# Altium schematic sheet ESP-MGMT.SchDoc
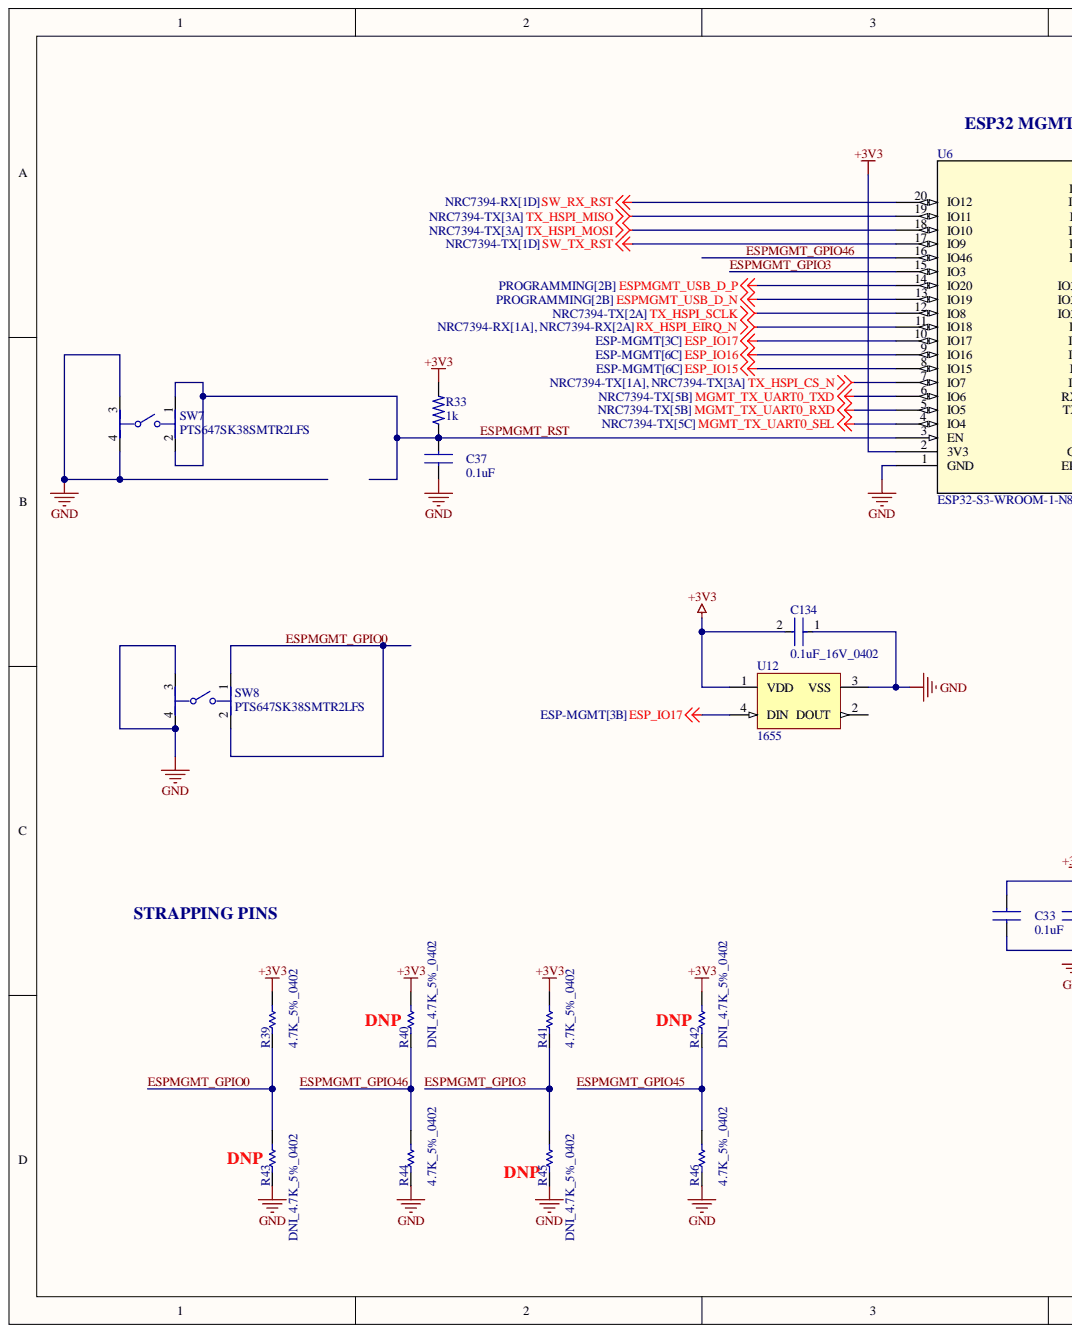
[diagram p0: ESP-MGMT.SchDoc, left]
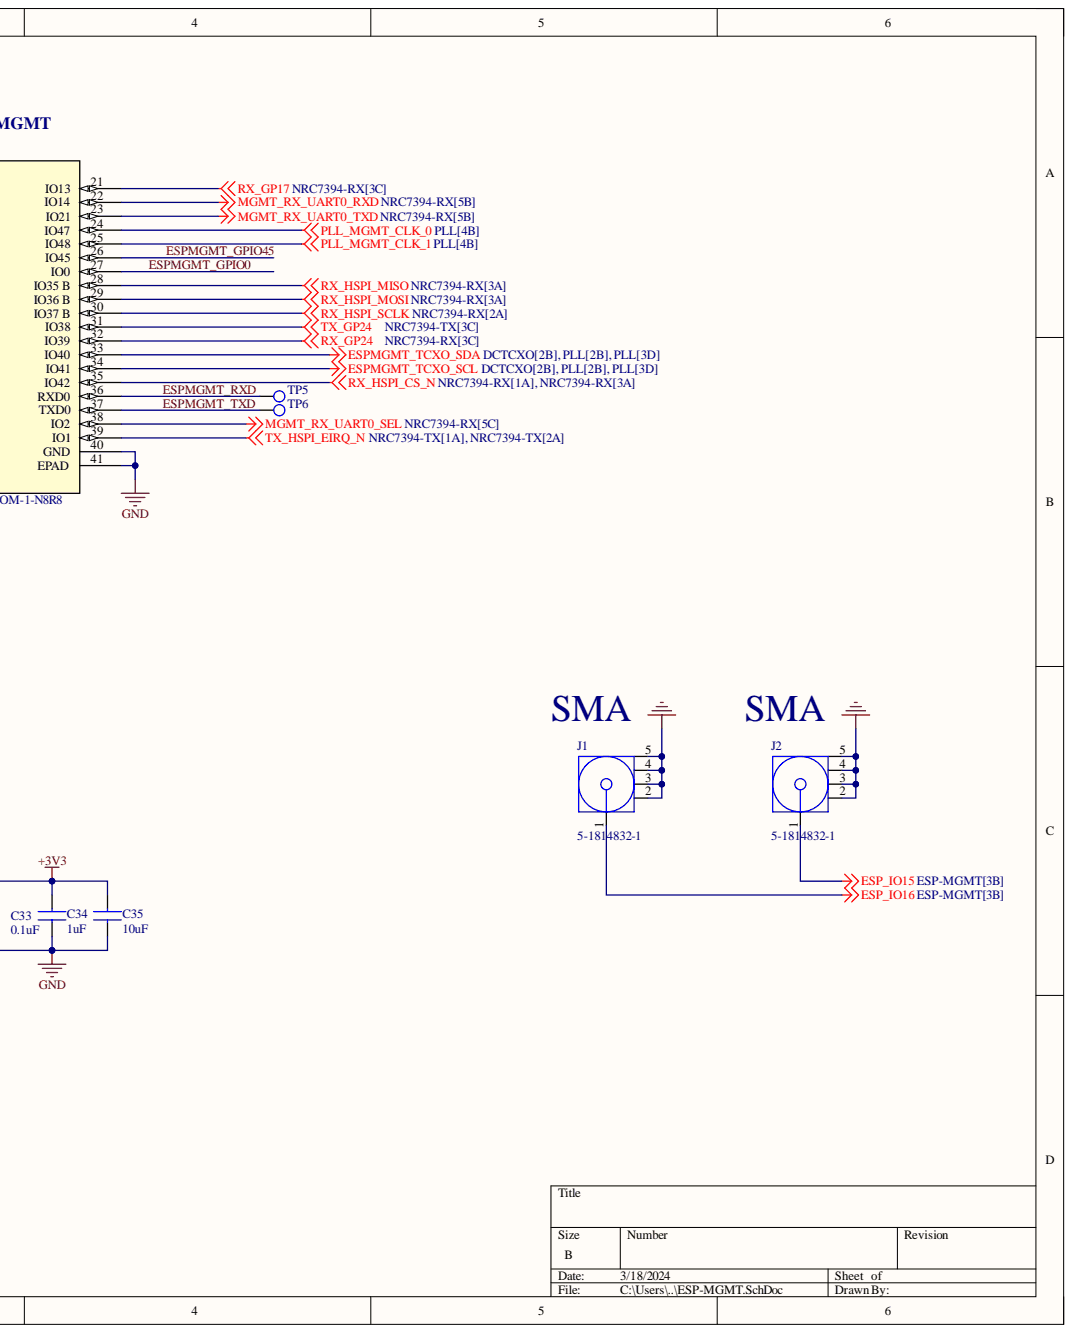
[diagram p1: ESP-MGMT.SchDoc, right]

## Parts
C33  0.1uF  [CAP_0402]
C34  1uF  [CAP_0402]
C35  10uF  [CAP_0402]
C37  0.1uF  [CAP_0402]
C134  0.1uF_16V_0402  [FP-C0402-BB-MFG]
J1  5-1814832-1  [TECO-5-1814832-1_V]
J2  5-1814832-1  [TECO-5-1814832-1_V]
R33  1k  [RES_0402]
R39  4.7K_5%_0402  [RESC1005X40X25LL05T05]
R40  DNI_4.7K_5%_0402  [RESC1005X40X25LL05T05]  (no BOM)
R41  4.7K_5%_0402  [RESC1005X40X25LL05T05]
R42  DNI_4.7K_5%_0402  [RESC1005X40X25LL05T05]  (no BOM)
R43  DNI_4.7K_5%_0402  [RESC1005X40X25LL05T05]  (no BOM)
R44  4.7K_5%_0402  [RESC1005X40X25LL05T05]
R45  DNI_4.7K_5%_0402  [RESC1005X40X25LL05T05]  (no BOM)
R46  4.7K_5%_0402  [RESC1005X40X25LL05T05]
SW7  PTS647SK38SMTR2LFS  [FP-PTS647SK38SMTR2LFS-MFG]
SW8  PTS647SK38SMTR2LFS  [FP-PTS647SK38SMTR2LFS-MFG]
TP5  Test Point 1MM  [TP_PAD-1MM]  (value not drawn)  (no BOM)
TP6  Test Point 1MM  [TP_PAD-1MM]  (value not drawn)  (no BOM)
U6  ESP32-S3-WROOM-1-N8R8  [ESP32-S3-WROOM-1_EXP]
U12  1655  [ADA-RGB-1655_V]

## Nets
+3V3: C33.2 C34.2 C35.2 C134.2 R33.2 R39.2 R40.2 R41.2 R42.2 U6.2(3V3) U12.1(VDD)
ESP_IO15: J2.1 U6.8(IO15)
ESP_IO16: J1.1 U6.9(IO16)
ESP_IO17: U6.10(IO17) U12.4(DIN)
ESPMGMT_TCXO_SCL: U6.34(IO41)
ESPMGMT_TCXO_SDA: U6.33(IO40)
ESPMGMT_USB_D_N: U6.13(IO19)
ESPMGMT_USB_D_P: U6.14(IO20)
GND: C33.1 C34.1 C35.1 C37.1 C134.1 J1.2 J1.3 J1.4 J1.5 J2.2 J2.3 J2.4 J2.5 R43.1 R44.1 R45.1 R46.1 SW7.3 SW7.4 SW8.3 SW8.4 U6.1(GND) U6.40(GND) U6.41(EPAD) U12.3(VSS)
MGMT_RX_UART0_RXD: U6.22(IO14)
MGMT_RX_UART0_SEL: U6.38(IO2)
MGMT_RX_UART0_TXD: U6.23(IO21)
MGMT_TX_UART0_RXD: U6.5(IO5)
MGMT_TX_UART0_SEL: U6.4(IO4)
MGMT_TX_UART0_TXD: U6.6(IO6)
PLL_MGMT_CLK_0: U6.24(IO47)
PLL_MGMT_CLK_1: U6.25(IO48)
RX_GP17: U6.21(IO13)
RX_GP24: U6.32(IO39)
RX_HSPI_CS_N: U6.35(IO42)
RX_HSPI_EIRQ_N: U6.11(IO18)
RX_HSPI_MISO: U6.28(IO35_B)
RX_HSPI_MOSI: U6.29(IO36_B)
RX_HSPI_SCLK: U6.30(IO37_B)
SW_RX_RST: U6.20(IO12)
SW_TX_RST: U6.17(IO9)
TX_GP24: U6.31(IO38)
TX_HSPI_CS_N: U6.7(IO7)
TX_HSPI_EIRQ_N: U6.39(IO1)
TX_HSPI_MISO: U6.19(IO11)
TX_HSPI_MOSI: U6.18(IO10)
TX_HSPI_SCLK: U6.12(IO8)
ESPMGMT_GPIO0: R39.1 R43.2 SW8.1 SW8.2 U6.27(IO0)
ESPMGMT_GPIO3: R41.1 R45.2 U6.15(IO3)
ESPMGMT_GPIO45: R42.1 R46.2 U6.26(IO45)
ESPMGMT_GPIO46: R40.1 R44.2 U6.16(IO46)
ESPMGMT_RST: C37.2 R33.1 SW7.1 SW7.2 U6.3(EN)
ESPMGMT_RXD: TP5.1 U6.36(RXD0)
ESPMGMT_TXD: TP6.1 U6.37(TXD0)

Not connected: U12 2(DOUT)

## Library data of the parts
C33 C37 = CAP_GCM155R71C104KA55J: Cap Ceramic 0.1uF 16V X7R 10% SMD 0402 125C Paper T/R; Manufacturer: Murata; Capacitance: 100nF; Case Code (Imperial): 0402; Case Code (Metric): 1005; Case/Package: 0402; Category: Ceramic Capacitors; Component Kind: Standard; ComponentLink1Description: https://datasheet.ciiva.com/11618/product-11618848.pdf?src-supplier=Avnet; ComponentLink1URL: https://datasheet.ciiva.com/11618/product-11618848.pdf?src-supplier=Avnet; ComponentLink2Description: https://datasheet.ciiva.com/16440/gcm155r71c104ka55j-16440393.pdf?src-supplier=Future+Electronics; ComponentLink2URL: https://datasheet.ciiva.com/16440/gcm155r71c104ka55j-16440393.pdf?src-supplier=Future+Electronics; ComponentLink3Description: https://datasheet.ciiva.com/29542/product-29542474.pdf?src-supplier=Digi-Key; ComponentLink3URL: https://datasheet.ciiva.com/29542/product-29542474.pdf?src-supplier=Digi-Key; ComponentLink4Description: https://datasheet.ciiva.com/29542/product-29542475.pdf?src-supplier=Digi-Key; ComponentLink4URL: https://datasheet.ciiva.com/29542/product-29542475.pdf?src-supplier=Digi-Key; Construction: Flat; Depth: 0.5mm; Dielectric: X7R; Height: 0.5mm; Lead Free: Lead Free; Length: 1mm; Manufacturer Part Number: GCM155R71C104KA55J; Max Operating Temperature: 125°C; Min Operating Temperature: -55°C; Mount: Surface Mount; Package Quantity: 1; Packaging: Tape and Reel; Pricing 1: 1=0.10000, 10=0.03500, 50=0.01920, 100=0.01560, 500=0.01116, 1000=0.00877, 2500=0.00798, 5000=0.00734 (USD); Radiation Hardening: No; Ratings: AEC-Q200; RoHS: Yes; Series: GCM; Stock 1: 10738061; Supplier 1: Digi-Key; Supplier Part Number 1: 490-7998-1-ND; Termination: SMD/SMT; Thickness: 0.022inch; Tolerance: 10%; Voltage Rating: 16V; Voltage Rating (DC): 16V; Width: 0.5mm; Library Name: LotusSound.IntLib
C34 = CAP_CL05A105KA5NQNC: Cap Ceramic 1uF 25V X5R 10% SMD 0402 85°C Cardboard T/R; Manufacturer: Samsung; Capacitance: 1uF; Case Code (Imperial): 0402; Case Code (Metric): 1005; Case/Package: 0402; Category: Ceramic Capacitors; Component Kind: Standard; ComponentLink1Description: https://datasheet.ciiva.com/3777/cl05a105ka5nqnc-3777709.pdf?src-supplier=Arrow+Electronics; ComponentLink1URL: https://datasheet.ciiva.com/3777/cl05a105ka5nqnc-3777709.pdf?src-supplier=Arrow+Electronics; ComponentLink2Description: https://datasheet.ciiva.com/25720/cl05a105ka5nqnc-25720771.pdf?src-supplier=Digi-Key; ComponentLink2URL: https://datasheet.ciiva.com/25720/cl05a105ka5nqnc-25720771.pdf?src-supplier=Digi-Key; ComponentLink3Description: https://datasheet.ciiva.com/29198/459147-da-01-en-vs-kondensator-0402-29198339.pdf?src-supplier=Conrad; ComponentLink3URL: https://datasheet.ciiva.com/29198/459147-da-01-en-vs-kondensator-0402-29198339.pdf?src-supplier=Conrad; Construction: Flat; Depth: 0.5mm; Dielectric: X5R; Height: 0.5mm; Lead Free: Lead Free; Length: 1mm; Manufacturer Part Number: CL05A105KA5NQNC; Material: Ceramic; Max Operating Temperature: 85°C; Min Operating Temperature: -55°C; Mount: Surface Mount; Package Quantity: 10000; Packaging: Tape and Reel; Pricing 1: 1=0.21000, 10=0.14900, 50=0.08460, 100=0.07000, 500=0.04910, 1000=0.04065, 2500=0.03784, 5000=0.03462 (USD); Radiation Hardening: No; RoHS: Yes; RoHS Compliant: Yes; Series: CL; Supplier 1: Digi-Key; Supplier Part Number 1: 1276-1445-6-ND; Thickness: 0.022inch; Tolerance: 10%; Voltage Rating: 25V; Width: 0.02inch; Library Name: LotusSound.IntLib
C35 = CAP_CL05A106MQ5NUNC: Cap Ceramic 10uF 6.3V X5R 20% SMD 0402 85°C Paper T/R; Manufacturer: Samsung; Capacitance: 10uF; Case Code (Imperial): 0402; Case Code (Metric): 1005; Case/Package: 0402; Category: Ceramic Capacitors; Component Kind: Standard; ComponentLink1Description: https://datasheet.ciiva.com/16437/cl05a106mq5nunc-16437356.pdf?src-supplier=Future+Electronics; ComponentLink1URL: https://datasheet.ciiva.com/16437/cl05a106mq5nunc-16437356.pdf?src-supplier=Future+Electronics; ComponentLink2Description: https://datasheet.ciiva.com/26877/getdatasheetpartid-355289-26877841.pdf?src-supplier=Verical; ComponentLink2URL: https://datasheet.ciiva.com/26877/getdatasheetpartid-355289-26877841.pdf?src-supplier=Verical; Construction: Flat; Depth: 0.5mm; Dielectric: X5R; Height: 0.5mm; Length: 1mm; Manufacturer Part Number: CL05A106MQ5NUNC; Max Operating Temperature: 85°C; Min Operating Temperature: -55°C; Mount: Surface Mount; Package Quantity: 1; Packaging: Tape and Reel; Pricing 1: 1=0.27000, 10=0.18400, 50=0.11020, 100=0.09300, 500=0.06362, 1000=0.05629, 2500=0.05384, 5000=0.04894 (USD); Radiation Hardening: No; RoHS: Yes; Series: CL; Stock 1: 3345553; Supplier 1: Digi-Key; Supplier Part Number 1: 1276-1451-1-ND; Thickness: 0.028inch; Tolerance: 20%; Voltage Rating: 6.3V; Width: 0.02inch; Library Name: LotusSound.IntLib
C134 = CMP-2008-02094-2: CAP CER 0.1UF 16V 20% X7R 0402; Manufacturer: Kemet; Manufacturer Part Number: C0402C104M4RACTU; Capacitance: 0.10 μF; Material: Ceramic; Temperature Coefficient: 15%; Tolerance: 0.2; Value: 0.10 μF; Automotive: No; Category: Cap; Component Kind: Standard; ComponentLink2Description: Datasheet; ComponentLink2URL: https://content.kemet.com/datasheets/KEM_C1002_X7R_SMD.pdf; Device Class L1: Passive Components; Device Class L2: Capacitors; Device Class L3: Ceramic Capacitors; Digikey Description: CAP CER 0.1UF 16V 20% X7R 0402; Height: 0.55mm; Ipc Land Pattern Name: CAPC10050X50; Lead Free: Yes; Library Name: Altium Content Vault; Mouser Description: Multilayer Ceramic Capacitors MLCC - SMD/SMT 16volts 0.1uF X7R 20%; Octopart: https://octopart.com/c0402c104m4ractu-kemet-10476575; Package: C0402-BB; RoHS: TRUE; Temperature: -55°C to +125°C; Temperature Characteristic: X7R; Temperature Range High: +125°C; Temperature Range Low: -55°C; Voltage Rating: 16 V
J1 J2 = CMP-2000-05415-1: SMA Connector, Pitch 5.08 mm, 1 Position, Height 9.5 mm, Tail Length 4 mm, -65 to 165 degC, RoHS, Bulk; Manufacturer: TE Connectivity; Coaxial Termination: Solder; Connector Body Style: StraightJack; Connector Mounting: PCBMount; Connector Type: SMACoaxial; Frequency Max: 3GHz; Impedance: 50ohm; Product Range: ZDCSeries; SVHC: NoSVHC(15-Jun-2015); Coaxial Cable Types: -; Component Kind: Standard; Contact Material: BerylliumCopper; Contact Plating: GoldPlatedContacts; Datasheet URL: http://www.onlinecomponents.com/productFiles/mf-AMP/eng_cd_1814832_a.pdf; Datasheet Version: Rev. B1, 03/2011; Library Name: Altium Content Vault; Manufacturer URL: http://www.te.com/; Package Description: SMA Connector, Pitch 5.08 mm, 1 Position, Height 9.5 mm, Tail Length 4 mm; Package Reference: 5-1814832-1
R33 = RES_RC0402JR-071KL: Res General Purpose Thick Film 0402 1K Ohm 5% 1/16W ±100ppm/°C Molded SMD Paper T/R; Manufacturer: Yageo; Max Power Dissipation: 63mW; Power Rating: 62.5mW; Resistance: 1kR; Voltage Rating: 50V; Case Code (Imperial): 0402; Case Code (Metric): 1005; Case/Package: 0402; Category: Chip SMD Resistors; Component Kind: Standard; ComponentLink1Description: https://datasheet.ciiva.com/2452/pyu-r-int-thick-7-2452755.pdf?src-supplier=Digi-Key; ComponentLink1URL: https://datasheet.ciiva.com/2452/pyu-r-int-thick-7-2452755.pdf?src-supplier=Digi-Key; ComponentLink2Description: https://datasheet.ciiva.com/2452/pyu-r-int-thick-7-2452756.pdf?src-supplier=Digi-Key; ComponentLink2URL: https://datasheet.ciiva.com/2452/pyu-r-int-thick-7-2452756.pdf?src-supplier=Digi-Key; ComponentLink3Description: https://datasheet.ciiva.com/2452/pyu-r-int-thick-7-2452757.pdf?src-supplier=Digi-Key; ComponentLink3URL: https://datasheet.ciiva.com/2452/pyu-r-int-thick-7-2452757.pdf?src-supplier=Digi-Key; ComponentLink4Description: https://datasheet.ciiva.com/17081/pyu-rc0402-51-rohs-l-6-r-17081438.pdf?src-supplier=Future+Electronics; ComponentLink4URL: https://datasheet.ciiva.com/17081/pyu-rc0402-51-rohs-l-6-r-17081438.pdf?src-supplier=Future+Electronics; ComponentLink5Description: https://datasheet.ciiva.com/7850/1018594-7850170.pdf?src-supplier=Element14; ComponentLink5URL: https://datasheet.ciiva.com/7850/1018594-7850170.pdf?src-supplier=Element14; ComponentLink6Description: https://datasheet.ciiva.com/7850/1018594-7850171.pdf?src-supplier=Element14; ComponentLink6URL: https://datasheet.ciiva.com/7850/1018594-7850171.pdf?src-supplier=Element14; ComponentLink7Description: https://datasheet.ciiva.com/7983/1018594-7983558.pdf?src-supplier=Element14; ComponentLink7URL: https://datasheet.ciiva.com/7983/1018594-7983558.pdf?src-supplier=Element14; Construction: Rectangular; Depth: 0.5mm; Features: Moisture Resistant; Height: 0.016inch; Lead Free: Lead Free; Length: 0.039inch; Manufacturer Part Number: RC0402JR-071KL; Max Operating Temperature: 155°C; Military Standard: Not; Min Operating Temperature: -55°C; Number of Terminations: 2; Package Quantity: 1; Packaging: Tape and Reel; Pricing 1: 1=0.10000, 10=0.01300, 100=0.00540, 1000=0.00244, 2500=0.00212, 5000=0.00175 (USD); REACH SVHC: No SVHC; Resistance Tolerance: 5%; Resistor Type: Thick Film; RoHS: Yes; RoHS Compliant: Yes; Series: RC; Size Code: 0402; Stock 1: 1442131; Supplier 1: Digi-Key; Supplier Part Number 1: 311-1.0KJRCT-ND; Temperature Coefficient: 100ppm/°C; Termination: SMD/SMT; Tolerance: 5%; Width: 0.02inch; Library Name: LotusSound.IntLib
R39-R46 = CMP-1011-00090-2: Manufacturer: Vishay Dale; Part Number: CRCW04024K70JNTD; Library Name: Altium Content Vault
  R39 R41 R44 R46: Component Kind: Standard
SW7 SW8 = CMP-10040-000033-1: TACT 4.5 X 4.5, 3.8 MM H, 2.5N,; Actuator Type: Standard; Illumination Type: Non-Illuminated; Mechanical Life: 100000Cycles; Operating Force: 250gf; Ratings: IP40; Switch Function: Off-Mom; Switch Travel: 0.25mm; Throw Configuration: SPST; Component Kind: Standard; Library Name: Altium Content Vault; Max Operating Temperature: 70°C; Min Operating Temperature: -20°C; Mount: Surface Mount; Packaging: Tape and Reel; Termination: Gull Wing
TP5 TP6 = TP_PAD-1MM: Library Name: LotusSound.IntLib
U6 = ESP32-S3-WROOM-1-N8R8: No Description Available; Mfr_Name: Espressif Systems; Component Kind: Standard; Library Name: ESP32-S3-WROOM-1-N8R8.SchLib; RefDes: RefDes; Type: Transceiver module
U12 = CMP-2000-05026-1: Intelligent Control LED Integrated Light Source, 3.5 to 5.3 V, -25 to 80 degC, 4-Pin SMD, RoHS, Tape and Reel; Manufacturer: Adafruit-Industries-LLC; Configuration: Discrete; Number of LEDs: 10; Utilized LED: WS2812(5050); Category: Optoelectronics; Color: Red,Green,Blue(RGB); Component Kind: Standard; Controller Type: -; Datasheet URL: http://datasheet.octopart.com/ADA1655-Adafruit-Industries-datasheet-32028139.pdf; Datasheet Version: May-13; Family: Addressable,Specialty; Interface: -; Library Name: Altium Content Vault; Manufacturer URL: https://www.adafruit.com/; Mounting Type: SurfaceMount; Package Description: 4-Pin Surface Mount Device, Body 5 x 5 mm; Package Reference: 1655; Series: Neopixel; Size / Dimension: 5.00mmLx5.00mmW
